# Altium schematic sheet TRINKIEROCKS P4.SchDoc
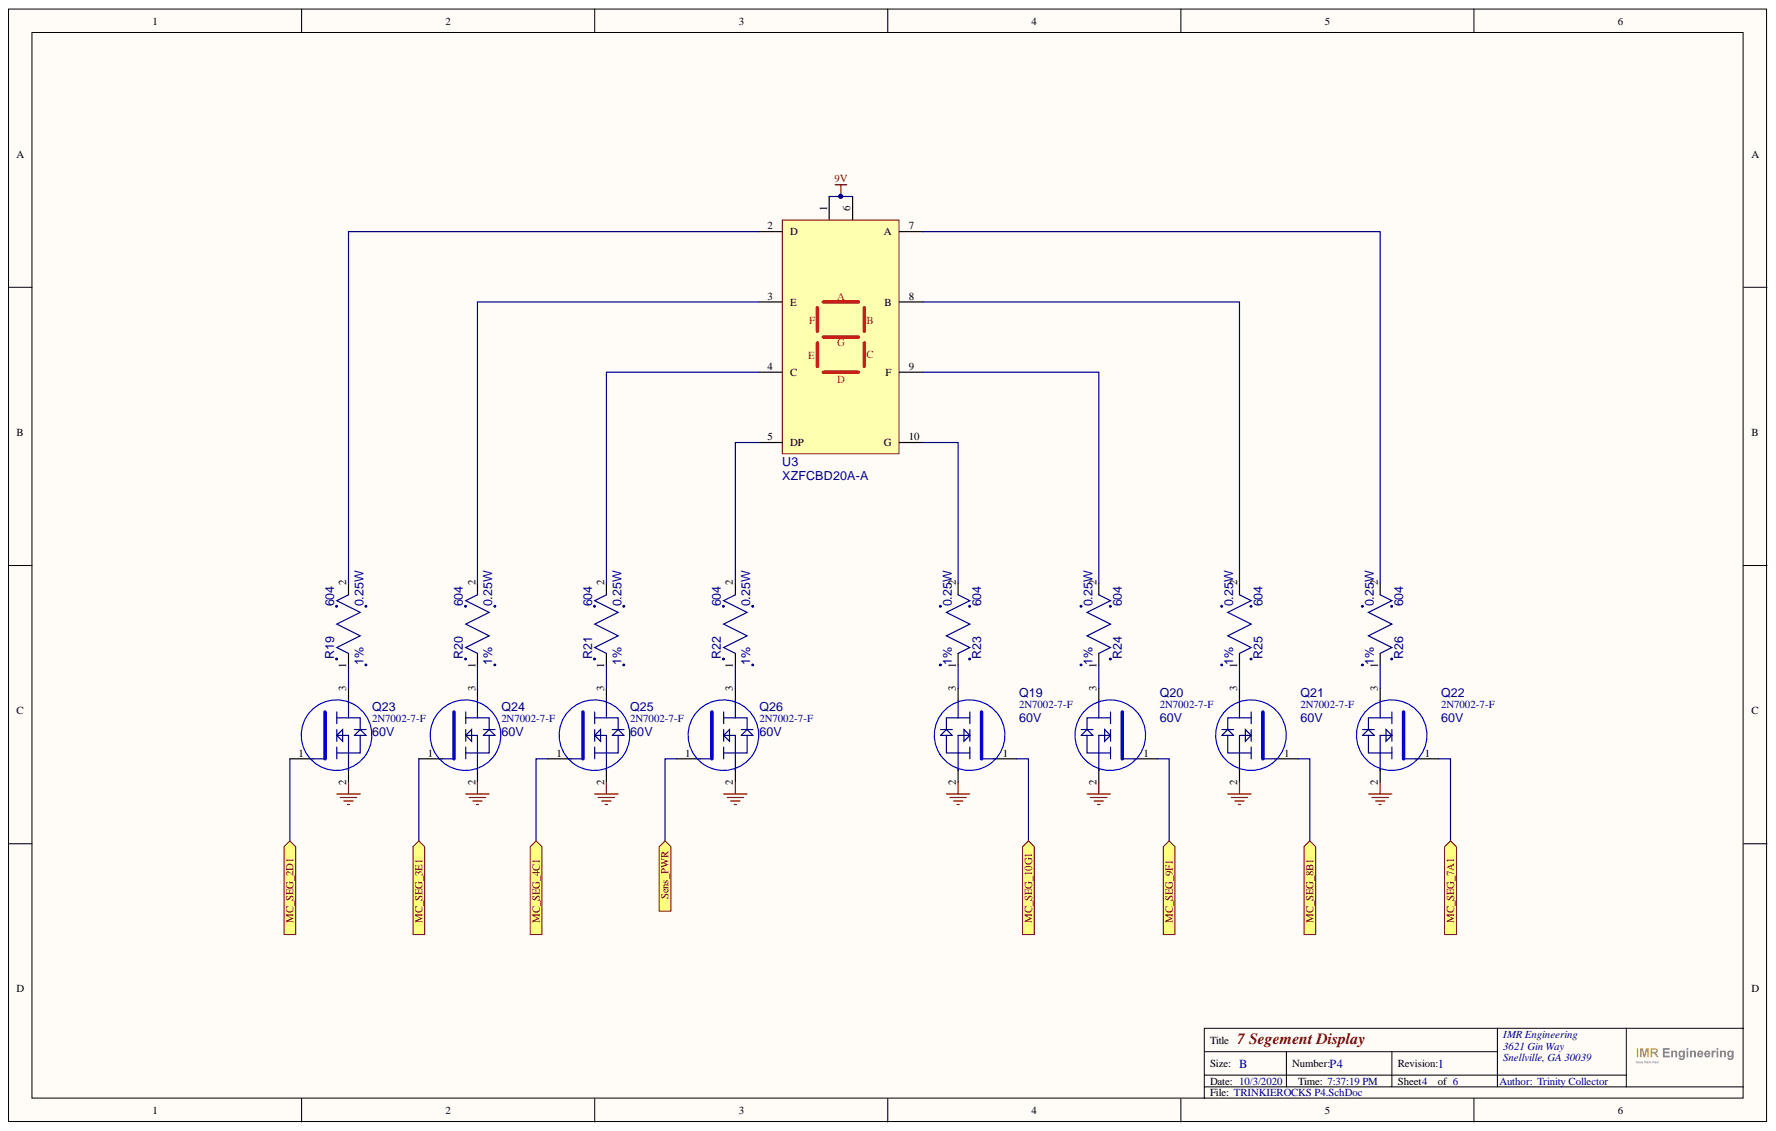
[diagram p0: TRINKIEROCKS P4.SchDoc]

## Parts
Q19  2N7002-7-F  value 60V  [SOT23 - TRANSISTOR]
Q20  2N7002-7-F  value 60V  [SOT23 - TRANSISTOR]
Q21  2N7002-7-F  value 60V  [SOT23 - TRANSISTOR]
Q22  2N7002-7-F  value 60V  [SOT23 - TRANSISTOR]
Q23  2N7002-7-F  value 60V  [SOT23 - TRANSISTOR]
Q24  2N7002-7-F  value 60V  [SOT23 - TRANSISTOR]
Q25  2N7002-7-F  value 60V  [SOT23 - TRANSISTOR]
Q26  2N7002-7-F  value 60V  [SOT23 - TRANSISTOR]
R19  value 604  POWER: 0.25W  TOLERANCE: 1%  [RES_0805]  (hidden comment: RK73H2ATTD6040F)
R20  value 604  POWER: 0.25W  TOLERANCE: 1%  [RES_0805]  (hidden comment: RK73H2ATTD6040F)
R21  value 604  POWER: 0.25W  TOLERANCE: 1%  [RES_0805]  (hidden comment: RK73H2ATTD6040F)
R22  value 604  POWER: 0.25W  TOLERANCE: 1%  [RES_0805]  (hidden comment: RK73H2ATTD6040F)
R23  value 604  POWER: 0.25W  TOLERANCE: 1%  [RES_0805]  (hidden comment: RK73H2ATTD6040F)
R24  value 604  POWER: 0.25W  TOLERANCE: 1%  [RES_0805]  (hidden comment: RK73H2ATTD6040F)
R25  value 604  POWER: 0.25W  TOLERANCE: 1%  [RES_0805]  (hidden comment: RK73H2ATTD6040F)
R26  value 604  POWER: 0.25W  TOLERANCE: 1%  [RES_0805]  (hidden comment: RK73H2ATTD6040F)
U3  XZFCBD20A-A  [7 SEG]

## Ports
MC_SEG_2D1, MC_SEG_3E1, MC_SEG_4C1, MC_SEG_7A1, MC_SEG_8B1, MC_SEG_9F1, MC_SEG_10G1, SENS_PWR

## Nets
9V: U3.1 U3.6
GND: Q19.2 Q20.2 Q21.2 Q22.2 Q23.2 Q24.2 Q25.2 Q26.2
MC_SEG_2D1: Q23.1 ; port MC_SEG_2D1
MC_SEG_3E1: Q24.1 ; port MC_SEG_3E1
MC_SEG_4C1: Q25.1 ; port MC_SEG_4C1
MC_SEG_7A1: Q22.1 ; port MC_SEG_7A1
MC_SEG_8B1: Q21.1 ; port MC_SEG_8B1
MC_SEG_9F1: Q20.1 ; port MC_SEG_9F1
MC_SEG_10G1: Q19.1 ; port MC_SEG_10G1
NetQ19_3: Q19.3 R23.1
NetQ20_3: Q20.3 R24.1
NetQ21_3: Q21.3 R25.1
NetQ22_3: Q22.3 R26.1
NetQ23_3: Q23.3 R19.1
NetQ24_3: Q24.3 R20.1
NetQ25_3: Q25.3 R21.1
NetQ26_1: Q26.1 ; port SENS_PWR
NetQ26_3: Q26.3 R22.1
NetR19_2: R19.2 U3.2(D)
NetR20_2: R20.2 U3.3(E)
NetR21_2: R21.2 U3.4(C)
NetR22_2: R22.2 U3.5(DP)
NetR23_2: R23.2 U3.10(G)
NetR24_2: R24.2 U3.9(F)
NetR25_2: R25.2 U3.8(B)
NetR26_2: R26.2 U3.7(A)

## Library data of the parts
Q19-Q26 = MOSFET_NCH_2N7002_115MA: MOSFET N CHN, VDS=60V, 115 mA, SOT 23; MFG: DIODES INC; Case/Package: SOT23; Component Kind: Standard; MFG_PN: 2N7002-7-F; Supplier 1: Digi-Key; Supplier Part Number 1: 2N7002-FDICT-ND; Library Name: Trinkie.SchLib
R19-R26 = RES_604_0805_1/4W_1%: RES 604 OHM 1% 1/4W 0805; MFG: KOA Speer Electronics, Inc.; Case/Package: 0805; Component Kind: Standard; MFG_PN: RK73H2ATTD6040F; Supplier 1: Digi-Key; Supplier Part Number 1: 2019-RK73H2ATTD6040FCT-ND; Library Name: Trinkie.SchLib
U3 = DISPLAY_7_SEG_LED_3V_10MA: DISPLAY 0.8" 1DIGIT BLUE CA SMD; MFG: SunLED; Case/Package: 10-SMD; Component Kind: Standard; MFG_PN: XZFCBD20A-A; Supplier 1: Digi-Key; Supplier Part Number 1: 1497-1486-1-ND; VALUE: XZFCBD20A-A; Library Name: Trinkie.SchLib
